# Altium schematic sheet voltage_sense.SchDoc
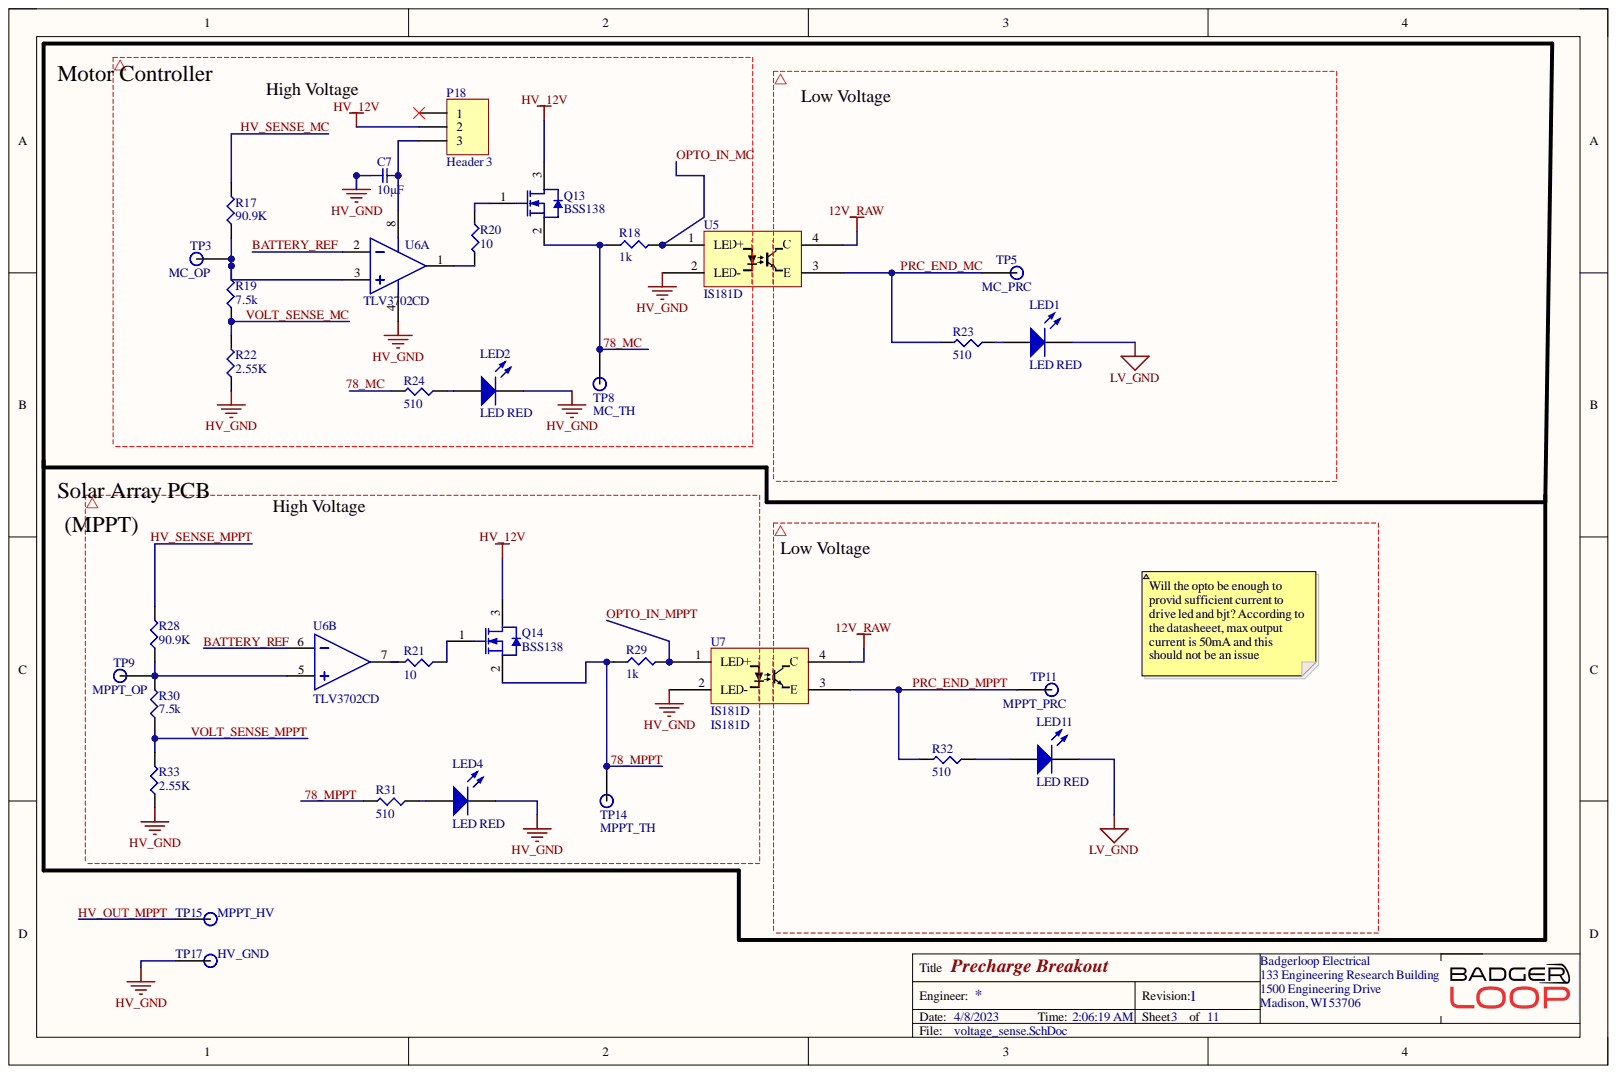
[diagram p0: voltage_sense.SchDoc]

## Parts
C7  value 10µF  [SMD-0805C]  (hidden comment: GCM21BR71A106KE22L)
LED1  LED RED  [LED 0603 ORANGE]
LED2  LED RED  [LED 0603 ORANGE]
LED4  LED RED  [LED 0603 ORANGE]
LED11  LED RED  [LED 0603 ORANGE]
P18  Header 3  [Pin Header 1x3 TH Pitch 2.54mm]
Q13  BSS138  [FAIR-SOT23-3_V]
Q14  BSS138  [FAIR-SOT23-3_V]
R17  value 90.9K  [SMD-0805-RES]  (hidden comment: ERJ-6GEYJ752V)
R18  value 1k  [SMD-0805-RES]  (hidden comment: ERJ-P06F1001V)
R19  value 7.5k  [SMD-0805-RES]  (hidden comment: ERJ-6GEYJ752V)
R20  10  [SMD-0805-RES]
R21  10  [SMD-0805-RES]
R22  value 2.55K  [SMD-0805-RES]  (hidden comment: ERJ-6GEYJ752V)
R23  value 510  [SMD-0805-RES]  (hidden comment: ERJ-P06J511V)
R24  value 510  [SMD-0805-RES]  (hidden comment: ERJ-P06J511V)
R28  value 90.9K  [SMD-0805-RES]  (hidden comment: ERJ-6GEYJ752V)
R29  value 1k  [SMD-0805-RES]  (hidden comment: ERJ-P06F1001V)
R30  value 7.5k  [SMD-0805-RES]  (hidden comment: ERJ-6GEYJ752V)
R31  value 510  [SMD-0805-RES]  (hidden comment: ERJ-P06J511V)
R32  value 510  [SMD-0805-RES]  (hidden comment: ERJ-P06J511V)
R33  value 2.55K  [SMD-0805-RES]  (hidden comment: ERJ-6GEYJ752V)
TP3  MC_OP  [Test Point]
TP5  MC_PRC  [Test Point]
TP8  MC_TH  [Test Point]
TP9  MPPT_OP  [Test Point]
TP11  MPPT_PRC  [Test Point]
TP14  MPPT_TH  [Test Point]
TP15  MPPT_HV  [Test Point]
TP17  HV_GND  [Test Point]
U5  IS181D  [IS181D]
U6  TLV3702CD  [TLV3702CD-Footprint-1]  (parts A,B)
U7  IS181D  [IS181D]

## Nets
12V_RAW: U5.4(C) U7.4(C)
HV_12V: P18.2 Q13.3 Q14.3
HV_GND: C7.1 LED2.2 LED4.2 R22.1 R33.1 TP17.1 U5.2(LED-) U6.4 U7.2(LED-)
LV_GND: LED1.2 LED11.2
78_MC: Q13.2 R18.1 R24.2 TP8.1
78_MPPT: Q14.2 R29.1 R31.2 TP14.1
BATTERY_REF: U6.2 U6.6
HV_OUT_MPPT: TP15.1
HV_SENSE_MC: R17.2
HV_SENSE_MPPT: R28.2
OPTO_IN_MC: R18.2 U5.1(LED+)
OPTO_IN_MPPT: R29.2 U7.1(LED+)
PRC_END_MC: R23.2 TP5.1 U5.3(E)
PRC_END_MPPT: R32.2 TP11.1 U7.3(E)
VOLT_SENSE_MC: R19.1 R22.2
VOLT_SENSE_MPPT: R30.1 R33.2
NetC7_2: C7.2 P18.3 U6.8
NetLED1_1: LED1.1 R23.1
NetLED2_1: LED2.1 R24.1
NetLED4_1: LED4.1 R31.1
NetLED11_1: LED11.1 R32.1
NetQ13_1: Q13.1 R20.1
NetQ14_1: Q14.1 R21.1
NetR17_1: R17.1 R19.2 TP3.1 U6.3
NetR20_2: R20.2 U6.1
NetR21_2: R21.2 U6.7
NetR28_1: R28.1 R30.2 TP9.1 U6.5

Not connected: P18 1

## Library data of the parts
C7 = CMP-001-00205-1: CAP CER 10UF 10V X7R 0805; Manufacturer: Murata Electronics North America; @ qty: 0; Minimum Quantity: 1; Tolerance: 10%; Unit Price (USD): 0.49; Applications: Automotive; Component Kind: Standard; Datasheets: http://www.murata.com/~/media/webrenewal/support/library/catalog/products/capacitor/mlcc/c03e.ashx; Design Item ID: GCM21BR71A106KE22L; Digi-Key Part Number: 490-10674-1-ND; Factory Stock: 0; Features: -; Height - Seated (Max): -; Image: //media.digikey.com/photos/Murata%20Photos/NCP,GCM%20SERIES%200805.jpg; Lead Spacing: -; Lead Style: -; Library Name: Altium Concord Pro on A365; Mounting Type: Surface Mount, MLCC; Operating Temperature: -55°C ~ 125°C; Package / Case: 0805 (2012 Metric); Packaging: Cut Tape (CT); Part Number: GCM21BR71A106KE22L; Part Status: Active; Quantity Available: 125344; Ratings: AEC-Q200; Series: GCM; Source: capacitors.SchLib; Temperature Coefficient: X7R; Voltage: 10V
LED1 LED2 LED4 LED11 = CMP-040-000018-1: A-0603UOC; Manufacturer: Lighthouse LEDs; Color: ORANGE; Minimum Quantity: 1; Availability: In Stock; Component Kind: Standard; ComponentLink1Description: Manufacturer; ComponentLink1URL: https://lighthouseleds.com/0603-smd-led-orange-ultra-bright-170-mcd.html; Datasheets: https://lighthouseleds.com/downloads/dl/file/id/11/0603_orange_amber_smd_led_datasheet.pdf; Design Item ID: LED ORANGE 0603; Library Name: Altium Concord Pro on A365; Manufacturer P/N: A-0603UOC; Package / Case: 0603; Source: misc.SchLib
P18 = CMP-002-00073-1: Header, 3-Pin; Component Kind: Standard; Design Item ID: Header 3; LatestRevisionDate: 17-Jul-2002; LatestRevisionNote: Re-released for DXP Platform.; Library Name: Altium Concord Pro on A365; Source: connector.SchLib; Type: Connector; Publisher: Altium Limited
Q13 Q14 = CMP-013-00021-4: N-Channel Logic Level Enhancement Mode Field Effect Transistor, 220 mA, 50 V, -55 to 150 degC, 3-Pin SOT-23, RoHS, Tape and Reel; Manufacturer: Fairchild; Elements: 1; Input Capacitance: 27pF; Nominal Dual Supply Voltage: 50V; Nominal Vgs: 1.3V; Package Version: Rev. 12, 03/2016; Power: 360mW; Rds On Max: 3.5Ohm; Resistance: 0.7Ohm; Typical Rise Time: 9ns; Case/Package: TO-236-3; Component Kind: Standard; Datasheet URL: https://www.fairchildsemi.com/datasheets/BS/BSS138.pdf; Datasheet Version: Rev. C, 10/2005; Library Name: Altium Concord Pro on A365; Max Operating Temperature: 150°C; Min Operating Temperature: -55°C; Mounting Technology: SM; Package Description: 3-Pin Small Outline Transistor, Body 2.92 x 1.325 mm, Pitch 0.95 mm; Package Quantity: 1; Package Reference: SOT23-3; Packaging: TapeandReel; Pins: 3; RoHS Compliant: True
R17 R19 R22 R28 R30 R33 = CMP-009-01751-1: RES SMD 7.5K OHM 5% 1/8W 0805; Manufacturer: Panasonic Electronic Components; @ qty: 1500; Factory Stock: 0; Minimum Quantity: 1; Power (Watts): 0.125W, 1/8W; Temperature Coefficient: ±200ppm/°C; Unit Price (USD): 0.00496; Component Kind: Standard; Composition: Thick Film; Datasheets: http://industrial.panasonic.com/www-cgi/jvcr13pz.cgi?E+PZ+3+AOA0001+ERJ6GEYJ752V+7+WW; Design Item ID: ERJ-6GEYJ752V; Digi-Key Part Number: P7.5KACT-ND; Failure Rate: -; Features: Automotive AEC-Q200; Image: //media.digikey.com/Renders/Panasonic%20Renders/ERJ-0805%20Pkg.jpg; Library Name: Altium Concord Pro on A365; Number of Terminations: 2; Operating Temperature: -55°C ~ 155°C; Package / Case: 0805 (2012 Metric); Packaging: Cut Tape (CT); Part Number: ERJ-6GEYJ752V; Part Status: Active; Quantity Available: 39543; Series: ERJ; Source: resistors.SchLib; Supplier Device Package: 0805; Tolerance: 5%
R18 R29 = CMP-009-02659-1: RES SMD 1K OHM 1% 1/2W 0805; Manufacturer: Panasonic Electronic Components; @ qty: 1500; Factory Stock: 0; Minimum Quantity: 1; Power (Watts): 0.5W, 1/2W; Temperature Coefficient: ±100ppm/°C; Unit Price (USD): 0.02534; Component Kind: Standard; Composition: Thick Film; Datasheets: https://industrial.panasonic.com/cdbs/www-data/pdf/RDA0000/AOA0000C243.pdf; Design Item ID: ERJ-P06F1001V; Digi-Key Part Number: P16058CT-ND; Failure Rate: -; Features: Automotive AEC-Q200, Pulse Withstanding; Image: //media.digikey.com/Renders/Panasonic%20Renders/ERJ-0805%20Pkg.jpg; Library Name: Altium Concord Pro on A365; Number of Terminations: 2; Operating Temperature: -55°C ~ 155°C; Package / Case: 0805 (2012 Metric); Packaging: Cut Tape (CT); Part Number: ERJ-P06F1001V; Part Status: Active; Quantity Available: 161264; Series: ERJ; Source: resistors.SchLib; Supplier Device Package: 0805; Tolerance: 1%
R20 R21 = ERJ-6GEYJ100V: RES SMD 10 OHM 5% 1/8W 0805; Manufacturer: Panasonic Electronic Components; @ qty: 1500; Factory Stock: 0; Minimum Quantity: 1; Power (Watts): 0.125W, 1/8W; Temperature Coefficient: ±200ppm/°C; Unit Price (USD): 0.00496; Component Kind: Standard; Composition: Thick Film; Datasheets: http://industrial.panasonic.com/www-cgi/jvcr13pz.cgi?E+PZ+3+AOA0001+ERJ6GEYJ100V+7+WW; Digi-Key Part Number: P10ACT-ND; Failure Rate: -; Features: Automotive AEC-Q200; Height - Seated (Max): 0.028" (0.70mm); Image: //media.digikey.com/Renders/Panasonic%20Renders/ERJ-0805%20Pkg.jpg; Library Name: resistors.SchLib; Number of Terminations: 2; Operating Temperature: -55°C ~ 155°C; Package / Case: 0805 (2012 Metric); Packaging: Cut Tape (CT); Part Number: ERJ-6GEYJ100V; Part Status: Active; Quantity Available: 282033; Series: ERJ; Size / Dimension: 0.079" L x 0.049" W (2.00mm x 1.25mm); Supplier Device Package: 0805; Tolerance: ±5%; Value: 10
R23 R24 R31 R32 = CMP-009-00645-1: RES SMD 510 OHM 5% 1/2W 0805; Manufacturer: Panasonic Electronic Components; @ qty: 1500; Factory Stock: 0; Minimum Quantity: 1; Power (Watts): 0.5W, 1/2W; Temperature Coefficient: ±200ppm/°C; Unit Price (USD): 0.01839; Component Kind: Standard; Composition: Thick Film; Datasheets: https://industrial.panasonic.com/cdbs/www-data/pdf/RDA0000/AOA0000C243.pdf; Design Item ID: ERJ-P06J511V; Digi-Key Part Number: P510ADCT-ND; Failure Rate: -; Features: Automotive AEC-Q200, Pulse Withstanding; Height - Seated (Max): 0.028" (0.70mm); Image: //media.digikey.com/Renders/Panasonic%20Renders/ERJ-0805%20Pkg.jpg; Library Name: Altium Concord Pro on A365; Number of Terminations: 2; Operating Temperature: -55°C ~ 155°C; Package / Case: 0805 (2012 Metric); Packaging: Cut Tape (CT); Part Number: ERJ-P06J511V; Part Status: Active; Quantity Available: 54515; Series: ERJ; Size / Dimension: 0.079" L x 0.049" W (2.00mm x 1.25mm); Source: resistors.SchLib; Supplier Device Package: 0805; Tolerance: 5%
TP3 TP5 TP8 TP9 TP11 TP14 TP15 TP17 = CMP-039-000000-1: SMD TEST POINT; Component Kind: Standard; Design Item ID: TP; Library Name: Altium Concord Pro on A365; Source: misc.SchLib
U5 U7 = CMP-020-000001-1: Transistor Output Optocoupler; Manufacturer: Isocom; Category: Optocouplers; Component Kind: Standard; ComponentLink1Description: https://datasheet.ciiva.com:443/pdfs/VipMasterIC/IC/ISCL/ISCL-S-A0004892693/ISCL-S-A0004892693-1.pdf?src-supplier=IHS+Markit; ComponentLink1URL: https://datasheet.ciiva.com:443/pdfs/VipMasterIC/IC/ISCL/ISCL-S-A0004892693/ISCL-S-A0004892693-1.pdf?src-supplier=IHS+Markit; ComponentLink2Description: https://datasheet.ciiva.com/7996/90946-7996982.pdf?src-supplier=Element14; ComponentLink2URL: https://datasheet.ciiva.com/7996/90946-7996982.pdf?src-supplier=Element14; Design Item ID: IS181D; Library Name: Altium Concord Pro on A365; Manufacturer Part Number: IS181D; Pricing 1: 1=0.59000, 10=0.36000, 100=0.20720, 1000=0.16218 (USD); RoHS: Yes; RoHS Compliant: Yes; Source: ic.SchLib; Stock 1: 15104
U6 = CMP-014-00010-1: Comparator, 2 Func, 7000uV Offset-Max, 240000ns Response Time, CMOS, PDSO8; Output Type: PUSH-PULL; Component Kind: Standard; Library Name: Altium Concord Pro on A365; Max Operating Temperature: 70°C; Min Operating Temperature: 0°C; RoHS Compliant: Yes
